# Altium schematic sheet 02-USER_IO.SchDoc(02-USER_IO)
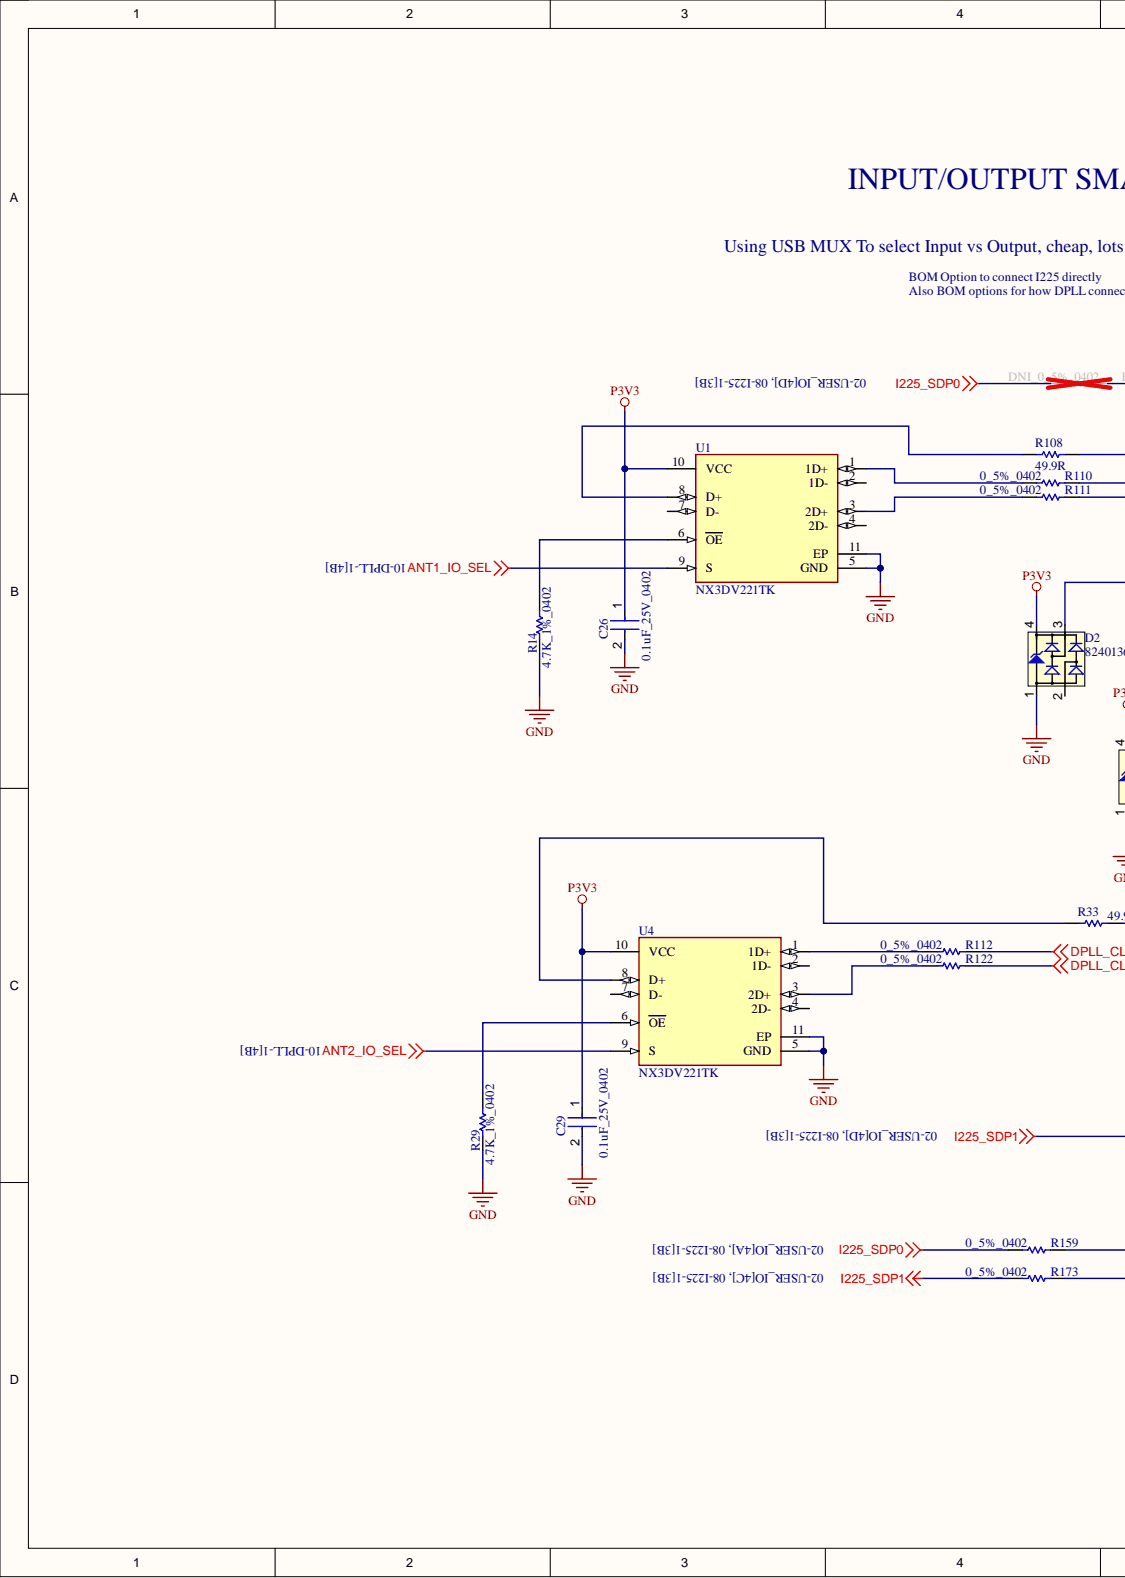
[diagram p0: 02-USER_IO.SchDoc, left]
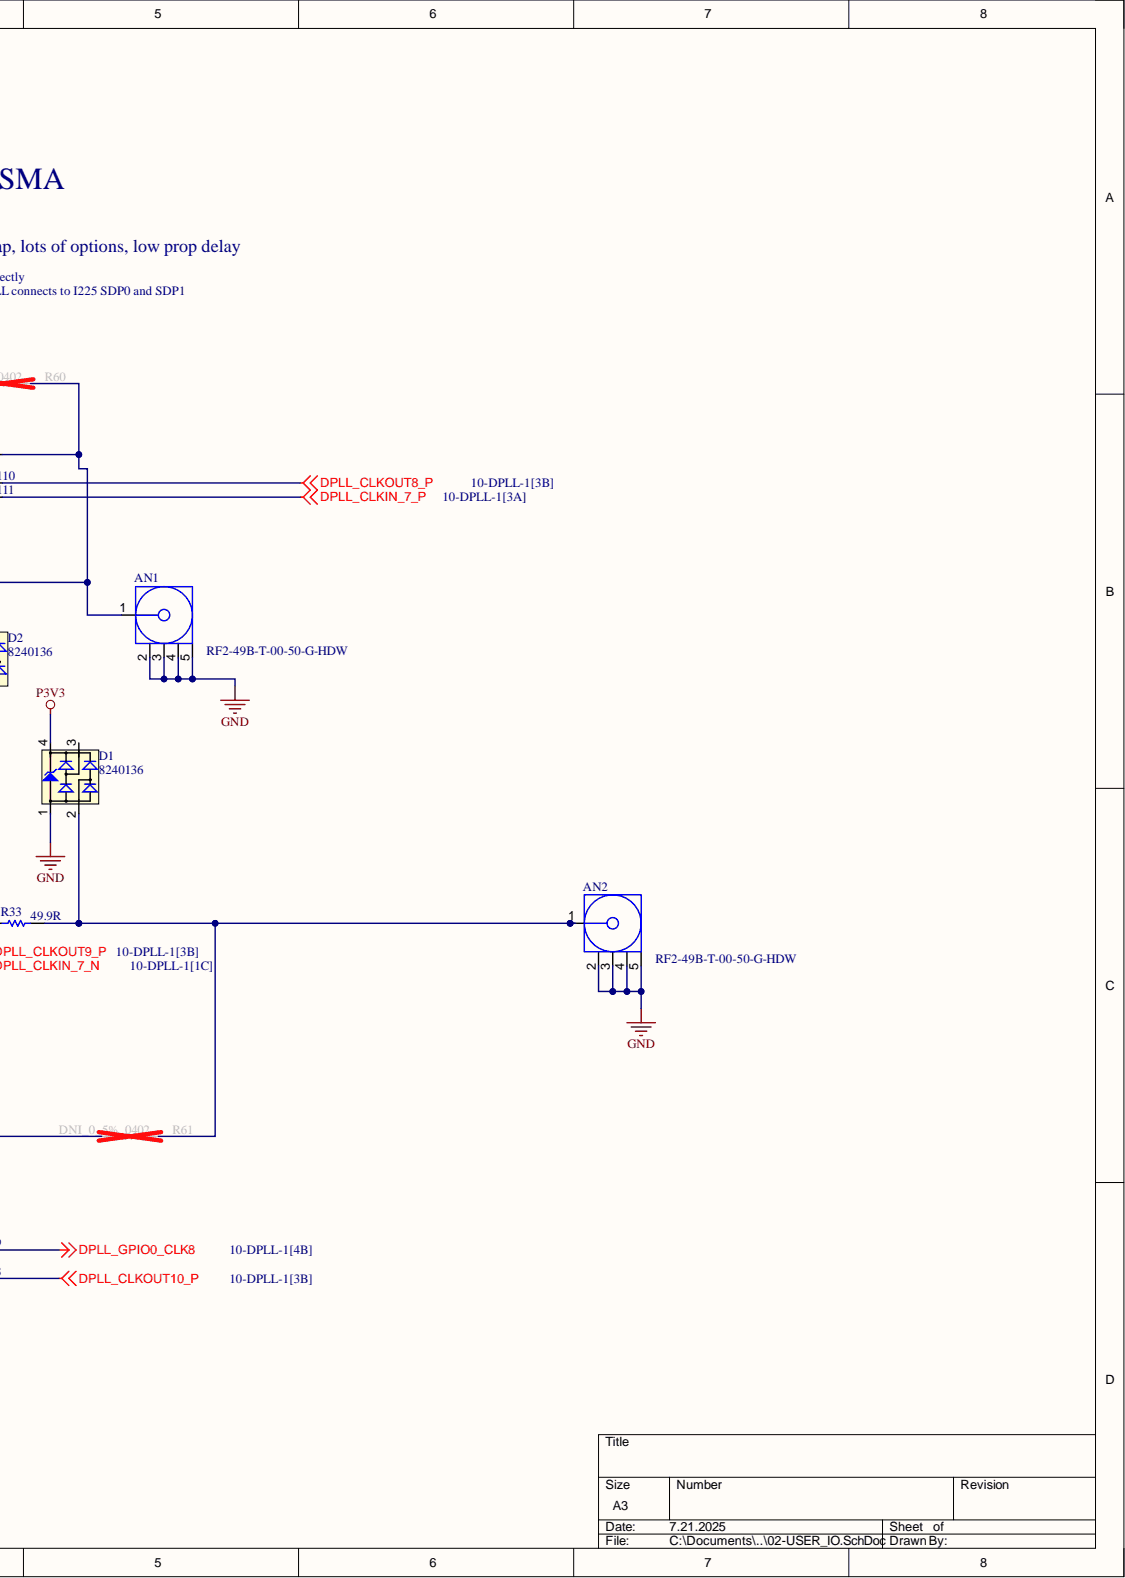
[diagram p1: 02-USER_IO.SchDoc, right]

## Parts
AN1  RF2-49B-T-00-50-G-HDW  [AMPH-901-143-6RFX_V]
AN2  RF2-49B-T-00-50-G-HDW  [AMPH-901-143-6RFX_V]
C26  0.1uF_25V_0402  [FP-0402-L_1_0_1-W_0_5_0_1-IPC_C]
C29  0.1uF_25V_0402  [FP-0402-L_1_0_1-W_0_5_0_1-IPC_C]
D1  8240136  [SOT143-4L]
D2  8240136  [SOT143-4L]
R14  4.7K_1%_0402  [RESC1005X40X25NL10T10]
R29  4.7K_1%_0402  [RESC1005X40X25NL10T10]
R33  49.9R  [RESC1608X55X25NL10T15]
R60  DNI_0_5%_0402  [RESC1005X40X25LL10T10]  (no BOM)
R61  DNI_0_5%_0402  [RESC1005X40X25LL10T10]  (no BOM)
R108  49.9R  [RESC1608X55X25NL10T15]
R110  0_5%_0402  [RESC1005X40X25LL10T10]
R111  0_5%_0402  [RESC1005X40X25LL10T10]
R112  0_5%_0402  [RESC1005X40X25LL10T10]
R122  0_5%_0402  [RESC1005X40X25LL10T10]
R159  0_5%_0402  [RESC1005X40X25LL10T10]
R173  0_5%_0402  [RESC1005X40X25LL10T10]
U1  NX3DV221TK  [FP-SOT650-2-MFG]
U4  NX3DV221TK  [FP-SOT650-2-MFG]

## Nets
ANT1_IO_SEL: U1.9(S)
ANT2_IO_SEL: U4.9(S)
DPLL_CLKIN_7_N: R122.2
DPLL_CLKIN_7_P: R111.2
DPLL_CLKOUT8_P: R110.2
DPLL_CLKOUT9_P: R112.2
DPLL_CLKOUT10_P: R173.2
DPLL_GPIO0_CLK8: R159.2
GND: AN1.2 AN1.3 AN1.4 AN1.5 AN2.2 AN2.3 AN2.4 AN2.5 C26.2 C29.2 D1.1 D2.1 R14.2 R29.2 U1.5(GND) U1.11(EP) U4.5(GND) U4.11(EP)
I225_SDP0: R60.1 R159.1
I225_SDP1: R61.1 R173.1
P3V3: C26.1 C29.1 D1.4 D2.4 U1.10(VCC) U4.10(VCC)
NetAN1_1: AN1.1 D2.3 R60.2 R108.1
NetAN2_1: AN2.1 D1.2 R33.2 R61.2
NetR14_1: R14.1 U1.6(OE)
NetR29_1: R29.1 U4.6(OE)
NetR33_1: R33.1 U4.8(D+)
NetR108_2: R108.2 U1.8(D+)
NetR110_1: R110.1 U1.1(1D+)
NetR111_1: R111.1 U1.3(2D+)
NetR112_1: R112.1 U4.1(1D+)
NetR122_1: R122.1 U4.3(2D+)

Not connected: D1 3; D2 2; U1 2(1D-) 4(2D-) 7(D-); U4 2(1D-) 4(2D-) 7(D-)

## Library data of the parts
AN1 AN2 = CMP-2000-05705-1: Coaxial connector, 50 Ohm, -65 to 165 degC, 5-Pin THD, RoHS, Bulk; Manufacturer: Amphenol; 3D Model: 901-143-6RFX.igs; Body Finish: Gold; Body Material: Brass; Catalog Drawings: 901-143-6RFXMountingHole; Center Contact Material: BerylliumCopper; Connector Style: SMA; Connector Type: Jack,FemaleSocket; Contact Termination: Solder; Dielectric Material: Polytetrafluoroethylene(PTFE); Frequency - Max: 18GHz; Impedance: 50Ohm; Online Catalog: SMA,RFX; Other Names: 901-143-6-RFX9011436RFXARFX1232; Cable Group: -; Category: Connectors,Interconnects; Center Contact Plating: Gold; Component Kind: Standard; Datasheet URL: http://www.amphenolrf.com/downloads/dl/file/id/2712/product/3102/901_143_6rfx_customer_drawing.pdf; Datasheet Version: Rev. C, 06/2010; Family: CoaxialConnectors(RF); Fastening Type: Threaded; Features: -; Housing Color: Gold; Ingress Protection: -; Library Name: Altium Content Vault; Manufacturer URL: http://www.amphenol.com/; Mounting Technology: ThroughHole; Mounting Type: ThroughHole,RightAngle; Operating Temperature: -65°C~165°C; Package Description: 5-Pin Through Hole Device, Body 7 x 7 mm, Pitch 2.54 mm; Package Reference: 901-143-6RFX; Packaging: Bulk; Series: -; Shield Termination: Solder; Standard Package: 1; Voltage Rating: -
C26 C29 = CMP-2008-02519-2: General Purpose Ceramic Capacitor, 0402, 100nF, 10%, X7R, 15%, 25V; Capacitance: 100nF; Case Code (Imperial): 0402; Case Code (Metric): 1005; Case/Package: 0402; Component Kind: Standard; Construction: Flat; Depth: 0.5mm; Dielectric: X7R; Lead Free: Lead Free; Length: 1mm; Library Name: Altium Content Vault; Max Operating Temperature: 125°C; Min Operating Temperature: -55°C; Mount: Surface Mount; Number of Pins: 2; Package Quantity: 1; Packaging: Tape and Reel; Radiation Hardening: No; REACH SVHC: No SVHC; RoHS Compliant: Yes; Series: -; Termination: SMD/SMT; Thickness: 0.022inch; Tolerance: 10%; Voltage: 25V; Voltage Rating: 25V; Voltage Rating (DC): 25V; Width: 0.02inch
D1 D2 = CMP-1486-00002-1: TVS Diode WE-TVS-HS, VRWM=3.3V; Manufacturer: Wuerth Elektronik; Reverse Stand Off Voltage: 3.3V; Component Kind: Standard; ComponentLink1Description: Manufacturer URL; ComponentLink1URL: http://www.we-online.com; ComponentLink2Description: Datasheet; ComponentLink2URL: http://katalog.we-online.de/kataloge/eisos/media/pdf/8240136.pdf; Library Name: Altium Content Vault; PackageReference: SOT143-4L; PartNumber: 8240136
R14 R29 = CMP-2002-05217-1: Manufacturer: Bourns; Part Number: CR0402-FX-4701GLF; Component Kind: Standard; Library Name: Altium Content Vault
R33 R108 = CMP-1659-00036-1: General Purpose Chip Resistor, 49.9 Ohm, +/- 1%, -55 to 155 degC, 0603 (1608 Metric), RoHS, Tape and Reel; Case\Package: LQFP; Interface: SPI; Max Supply Voltage: 3.63 V; Min Supply Voltage: 2.97 V; Component Kind: Standard; ComponentLink1Description: Manufacturer URL; ComponentLink1URL: http://www.yageo.com/; ComponentLink2Description: Datasheet; ComponentLink2URL: https://datasheet.ciiva.com/11808/0900766b80f96399-11808223.pdf; DatasheetVersion: Rev. 4, 04/2009; Library Name: Altium Content Vault; Max Operating Temperature: 85 degC; Min Operating Temperature: -40 degC; Package Quantity: 250; PackageDescription: 2-Pin Surface Mount Device, Body 1.6 x 0.8 mm; PackageReference: 0603; Packaging: Tray; Pins: 48; RoHSCompliant: true
R60 R61 R110-R112 R122 R159 R173 = CMP-2002-04569-1: Manufacturer: KOA Speer; Part Number: RK73Z1ETTP; Library Name: Altium Content Vault
  R110-R112 R122 R159 R173: Component Kind: Standard
U1 U4 = CMP-10103-000005-1: USB Switch IC 1 Channel 10-HVSON (3x3); Component Kind: Standard; Library Name: Altium Content Vault; RoHS Compliant: Yes
